# Altium schematic sheet PerifericaRelè.SchDoc(PerifericaRelè)
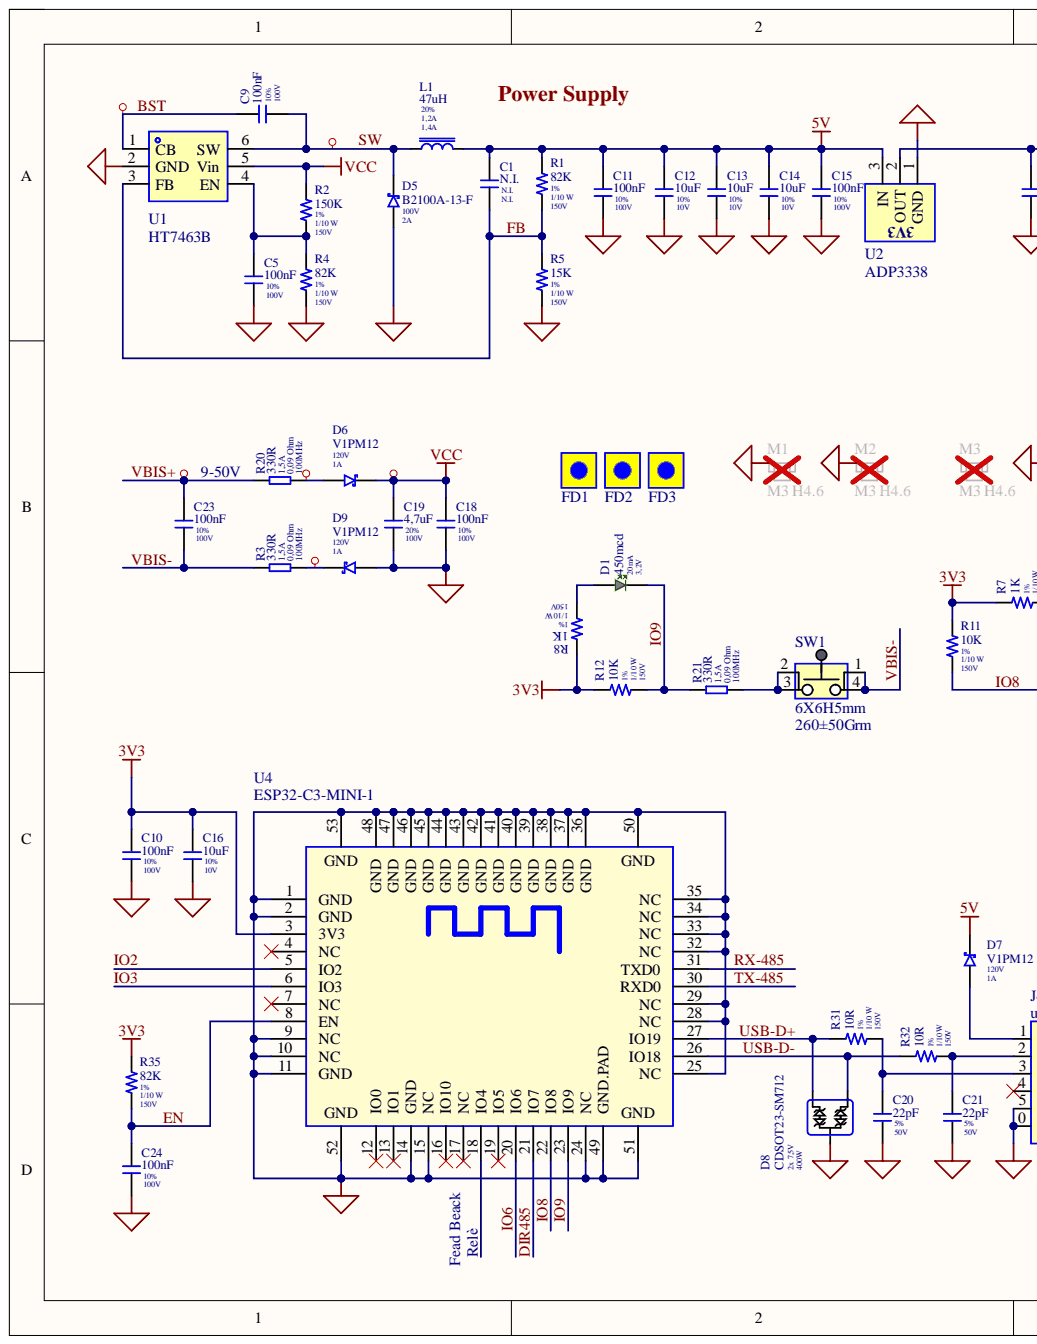
[diagram p0: PerifericaRelè.SchDoc, left]
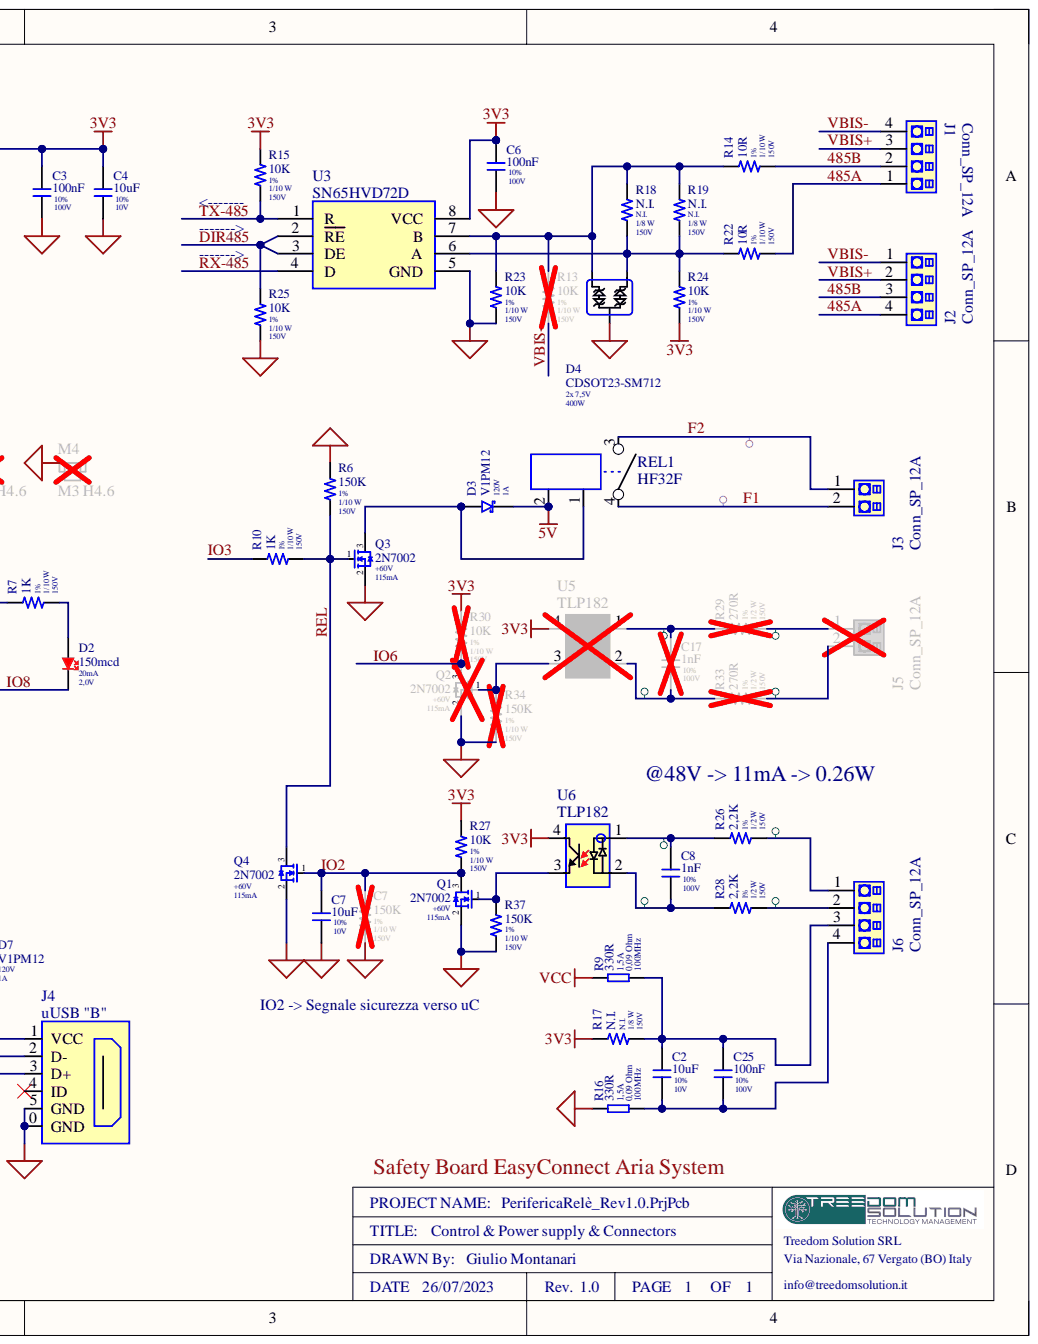
[diagram p1: PerifericaRelè.SchDoc, right]

## Parts
C1  N.I.  [CAP_0805]
C2  10uF  Tolerance: 10%  Voltage: 10V  [CAP_0805]
C3  100nF  Tolerance: 10%  Voltage: 100V  [CAP_0805]
C4  10uF  Tolerance: 10%  Voltage: 10V  [CAP_0805]
C5  100nF  Tolerance: 10%  Voltage: 100V  [CAP_0805]
C6  100nF  Tolerance: 10%  Voltage: 100V  [CAP_0805]
C7  150K  Power Rate: 1/10 W  Tolerance: 1%  Work Voltage: 150V  [RES_0805]
C7  10uF  Tolerance: 10%  Voltage: 10V  [CAP_0805]
C8  1nF  Tolerance: 10%  Voltage: 100V  [CAP_0805]
C9  100nF  Tolerance: 10%  Voltage: 100V  [CAP_0805]
C10  100nF  Tolerance: 10%  Voltage: 100V  [CAP_0805]
C11  100nF  Tolerance: 10%  Voltage: 100V  [CAP_0805]
C12  10uF  Tolerance: 10%  Voltage: 10V  [CAP_0805]
C13  10uF  Tolerance: 10%  Voltage: 10V  [CAP_0805]
C14  10uF  Tolerance: 10%  Voltage: 10V  [CAP_0805]
C15  100nF  Tolerance: 10%  Voltage: 100V  [CAP_0805]
C16  10uF  Tolerance: 10%  Voltage: 10V  [CAP_0805]
C17  1nF  Tolerance: 10%  Voltage: 100V  [CAP_0805]
C18  100nF  Tolerance: 10%  Voltage: 100V  [CAP_0805]
C19  4,7uF  Tolerance: 20%  Voltage: 100V  [CAP_1210]
C20  22pF  Tolerance: 5%  Voltage: 50V  [CAP_0805]
C21  22pF  Tolerance: 5%  Voltage: 50V  [CAP_0805]
C23  100nF  Tolerance: 10%  Voltage: 100V  [CAP_0805]
C24  100nF  Tolerance: 10%  Voltage: 100V  [CAP_0805]
C25  100nF  Tolerance: 10%  Voltage: 100V  [CAP_0805]
D1  450mcd  Current: 20mA  Voltage: 3,2V  [LED_G_0805]
D2  150mcd  Current: 20mA  Voltage: 2,0V  [LED_R_0805]
D3  V1PM12  Current MAX: 1A  Voltage MAX: 120V  [DO-219AD]
D4  CDSOT23-SM712  [SOT23]
D5  B2100A-13-F  Current MAX: 2A  Voltage MAX: 100V  [DO-214AC]
D6  V1PM12  Current MAX: 1A  Voltage MAX: 120V  [DO-219AD]
D7  V1PM12  Current MAX: 1A  Voltage MAX: 120V  [DO-219AD]
D8  CDSOT23-SM712  Power: 400W  Voltage: 2x 7,5V  [SOT23]
D9  V1PM12  Current MAX: 1A  Voltage MAX: 120V  [DO-219AD]
FD1  Fiducial  [FIDUCIAL_TL]  (value not drawn)  (no BOM)
FD2  Fiducial  [FIDUCIAL_TL]  (value not drawn)  (no BOM)
FD3  Fiducial  [FIDUCIAL_TL]  (value not drawn)  (no BOM)
J1  Conn_SP_12A  [LSF_SMT_3.50_04P]
J2  Conn_SP_12A  [LSF_SMT_3.50_04P]
J3  Conn_SP_12A  [LSF_SMT_3.50_02P]
J4  uUSB "B"  [Micro_USB_B_VE_PTH]
J5  Conn_SP_12A  [LSF_SMT_3.50_02P]
J6  Conn_SP_12A  [LSF_SMT_3.50_04P]
L1  Inductance: 47uH  Irms: 1,2A  Isat: 1,4A  Tolerance: 20%  [IND_6045]
M1  M3 H4.6  [Dist_SMD_REV_M3_H4.9]
M2  M3 H4.6  [Dist_SMD_REV_M3_H4.9]
M3  M3 H4.6  [Dist_SMD_REV_M3_H4.9]
M4  M3 H4.6  [Dist_SMD_REV_M3_H4.9]
Q1  2N7002  Current MAX: 115mA  Voltage MAX: +60V  [SOT23]
Q2  2N7002  Current MAX: 115mA  Voltage MAX: +60V  [SOT23]
Q3  2N7002  Current MAX: 115mA  Voltage MAX: +60V  [SOT23]
Q4  2N7002  Current MAX: 115mA  Voltage MAX: +60V  [SOT23]
R1  82K  Power Rate: 1/10 W  Tolerance: 1%  Work Voltage: 150V  [RES_0805]
R2  150K  Power Rate: 1/10 W  Tolerance: 1%  Work Voltage: 150V  [RES_0805]
R3  Current: 1,5A  DC Resistance: 0,09 Ohm  FRQ: 100MHz  Inductance: 330R  [FER_0805]  (hidden comment: Ferrite)
R4  82K  Power Rate: 1/10 W  Tolerance: 1%  Work Voltage: 150V  [RES_0805]
R5  15K  Power Rate: 1/10 W  Tolerance: 1%  Work Voltage: 150V  [RES_0805]
R6  150K  Power Rate: 1/10 W  Tolerance: 1%  Work Voltage: 150V  [RES_0805]
R7  1K  Power Rate: 1/10 W  Tolerance: 1%  Work Voltage: 150V  [RES_0805]
R8  1K  Power Rate: 1/10 W  Tolerance: 1%  Work Voltage: 150V  [RES_0805]
R9  Current: 1,5A  DC Resistance: 0,09 Ohm  FRQ: 100MHz  Inductance: 330R  [FER_0805]  (hidden comment: Ferrite)
R10  1K  Power Rate: 1/10 W  Tolerance: 1%  Work Voltage: 150V  [RES_0805]
R11  10K  Power Rate: 1/10 W  Tolerance: 1%  Work Voltage: 150V  [RES_0805]
R12  10K  Power Rate: 1/10 W  Tolerance: 1%  Work Voltage: 150V  [RES_0805]
R13  10K  Power Rate: 1/10 W  Tolerance: 1%  Work Voltage: 150V  [RES_0805]
R14  10R  Power Rate: 1/10 W  Tolerance: 1%  Work Voltage: 150V  [RES_0805]
R15  10K  Tolerance: 1%  Work Voltage: 150V  [RES_0805]
R16  Current: 1,5A  DC Resistance: 0,09 Ohm  FRQ: 100MHz  Inductance: 330R  [FER_0805]  (hidden comment: Ferrite)
R17  N.I.  Power Rate: 1/8 W  Work Voltage: 150V  [RES_0805]
R18  N.I.  Power Rate: 1/8 W  Work Voltage: 150V  [RES_0805]
R19  N.I.  Power Rate: 1/8 W  Work Voltage: 150V  [RES_0805]
R20  Current: 1,5A  DC Resistance: 0,09 Ohm  FRQ: 100MHz  Inductance: 330R  [FER_0805]  (hidden comment: Ferrite)
R21  Current: 1,5A  DC Resistance: 0,09 Ohm  FRQ: 100MHz  Inductance: 330R  [FER_0805]  (hidden comment: Ferrite)
R22  10R  Power Rate: 1/10 W  Tolerance: 1%  Work Voltage: 150V  [RES_0805]
R23  10K  Power Rate: 1/10 W  Tolerance: 1%  Work Voltage: 150V  [RES_0805]
R24  10K  Power Rate: 1/10 W  Tolerance: 1%  Work Voltage: 150V  [RES_0805]
R25  10K  Power Rate: 1/10 W  Tolerance: 1%  Work Voltage: 150V  [RES_0805]
R26  2,2K  Power Rate: 1/2 W  Tolerance: 1%  Work Voltage: 150V  [RES_0805]
R27  10K  Tolerance: 1%  Work Voltage: 150V  [RES_0805]
R28  2,2K  Power Rate: 1/2 W  Tolerance: 1%  Work Voltage: 150V  [RES_0805]
R29  270R  Power Rate: 1/2 W  Tolerance: 1%  Work Voltage: 150V  [RES_0805]
R30  10K  Tolerance: 1%  Work Voltage: 150V  [RES_0805]
R31  10R  Power Rate: 1/10 W  Tolerance: 1%  Work Voltage: 150V  [RES_0805]
R32  10R  Power Rate: 1/10 W  Tolerance: 1%  Work Voltage: 150V  [RES_0805]
R33  270R  Power Rate: 1/2 W  Tolerance: 1%  Work Voltage: 150V  [RES_0805]
R34  150K  Power Rate: 1/10 W  Tolerance: 1%  Work Voltage: 150V  [RES_0805]
R35  82K  Power Rate: 1/10 W  Tolerance: 1%  Work Voltage: 150V  [RES_0805]
R37  150K  Power Rate: 1/10 W  Tolerance: 1%  Work Voltage: 150V  [RES_0805]
REL1  HF32F  [REL_184x102h157]
SW1  6X6H5mm  Force: 260±50Grm  [PULS 6x6h5 SMD]
U1  HT7463B  [SOT23-6]
U2  ADP3338  [SOT223]
U3  SN65HVD72D  [SO-8]
U4  ESP32-C3-MINI-1  [ESP32-C3-MINI-1]
U5  TLP182  [SOP-4]
U6  TLP182  [SOP-4]

## Nets
3V3: C3.1 C4.1 C6.1 C10.1 C16.1 R7.1 R8.1 R11.1 R12.1 R15.1 R17.1 R24.2 R27.1 R30.1 R35.1 U2.2 U3.8(VCC) U4.3(3V3) U5.4 U6.4
5V: C1.1 C11.1 C12.1 C13.1 C14.1 C15.1 D3.K D7.K L1.2 R1.1 REL1.2 U2.3
GND: C3.2 C4.2 C5.2 C6.2 C7.2 C10.2 C11.2 C12.2 C13.2 C14.2 C15.2 C16.2 C18.2 C19.2 C20.2 C21.2 C24.2 D4.3 D5.A D8.3 D9.A J4.0(GND) J4.5(GND) M1.0 M2.0 M4.0 Q1.2 Q2.2 Q3.2 Q4.2 R4.2 R5.2 R6.1 R16.1 R23.2 R25.2 R34.2 R37.2 U1.2(GND) U2.1 U3.5(GND) U4.1(GND) U4.2(GND) U4.9(NC) U4.10(NC) U4.11(GND) U4.14(GND) U4.15(NC) U4.24(NC) U4.25(NC) U4.28(NC) U4.29(NC) U4.32(NC) U4.33(NC) U4.34(NC) U4.35(NC) U4.36(GND) U4.37(GND) U4.38(GND) U4.39(GND) U4.40(GND) U4.41(GND) U4.42(GND) U4.43(GND) U4.44(GND) U4.45(GND) U4.46(GND) U4.47(GND) U4.48(GND) U4.49(GND.PAD) U4.50 U4.51 U4.52 U4.53
VCC: C18.1 C19.1 D6.K R2.1 R9.1 U1.5(Vin)
485A: J1.1 J2.4 R22.2
485B: J1.2 J2.3 R14.2
BST: C9.1 U1.1(CB)
DIR485: R25.1 U3.2(RE) U3.3(DE) U4.21(IO7)
EN: C24.1 R35.2 U4.8(EN)
F1: J3.2 REL1.4
F2: J3.1 REL1.3
FB: C1.2 R1.2 R5.1 U1.3(FB)
IO2: C7.1 Q1.3 Q4.1 R27.2 U4.5(IO2)
IO3: R10.1 U4.6(IO3)
IO6: Q2.3 R30.2 U4.20(IO6)
IO8: D2.K R11.2 U4.22(IO8)
IO9: D1.K R12.2 R21.1 U4.23(IO9)
REL: Q3.1 Q4.3 R6.2 R10.2
RX-485: U3.4(D) U4.31(TXD0)
SW: C9.2 D5.K L1.1 U1.6(SW)
TX-485: R15.2 U3.1(R) U4.30(RXD0)
USB-D+: D8.1 R31.1 U4.27(IO19)
USB-D-: D8.2 R32.1 U4.26(IO18)
VBIS+: C23.1 J1.3 J2.2 R20.1
VBIS-: C23.2 J1.4 J2.1 R3.1 R13.2 SW1.1 SW1.4
NetC2_1: C2.1 C25.1 J6.3 R9.2 R17.2
NetC2_2: C2.2 C25.2 J6.4 R16.2
NetC5_1: C5.1 R2.2 R4.1 U1.4(EN)
NetC8_1: C8.1 R26.1 U6.1
NetC8_2: C8.2 R28.1 U6.2
NetC17_1: C17.1 R29.1 U5.1
NetC17_2: C17.2 R33.1 U5.2
NetC20_1: C20.1 J4.3(D+) R31.2
NetC21_1: C21.1 J4.2(D-) R32.2
NetD1_A: D1.A R8.2
NetD2_A: D2.A R7.2
NetD3_A: D3.A Q3.3 REL1.1
NetD4_1: D4.1 R13.1 R14.1 R18.1 R19.1 R23.1 U3.7(B)
NetD4_2: D4.2 R18.2 R19.2 R22.1 R24.1 U3.6(A)
NetD6_A: D6.A R20.2
NetD7_A: D7.A J4.1(VCC)
NetD9_K: D9.K R3.2
NetJ4_4: J4.4(ID)
NetJ5_1: J5.1 R29.2
NetJ5_2: J5.2 R33.2
NetJ6_1: J6.1 R26.2
NetJ6_2: J6.2 R28.2
NetM3_0: M3.0
NetQ1_1: Q1.1 R37.1 U6.3
NetQ2_1: Q2.1 R34.1 U5.3
NetR21_2: R21.2 SW1.2 SW1.3
NetU4_4: U4.4(NC)
NetU4_7: U4.7(NC)
NetU4_12: U4.12(IO0)
NetU4_13: U4.13(IO1)
NetU4_16: U4.16(IO10)
NetU4_17: U4.17(NC)
NetU4_18: U4.18(IO4)
NetU4_19: U4.19(IO5)

## Library data of the parts
C1 = N.I. 0805: Cap N.I. 0805; Database Table Name: Capacitors CERAMIC; Temp Range: -55°C to +125°C; Capacitance: N.I.; Component Kind: Standard; Library Name: Database_Libs.DbLib; Part Number: N.I. 0805; Price 1: 0; Tolerance: N.I.; Voltage: N.I.
C2 C4 C7 C12-C14 C16 = COCS 557061000106: Cap 10uF+/-10%;  10V; Case 0805; X5R; Manufactuer 1: Samsung Electro-Mechanics; Manufactuer 2: Taiyo Yoden  Taiyo Yuden; Manufactuer 3: Walsin; Database Table Name: Capacitors CERAMIC; Man 1 P\N: CL21A106KPFNNNE; Man 2 P\N: LMK212ABJ106KG-T; Man 3 P\N: 0805X106K100CT; Mounting Method: SMD; Temp Range: -55°C to +125°C; Capacitance: 10uF; Component Kind: Standard; ComponentLink1Description: Data Scheet Samsung; ComponentLink2Description: Data Scheet Taiyo Yoden; ComponentLink3Description: Data Scheet Walsin; Library Name: Database_Libs.DbLib; Part Number: COCS 557061000106; Price 1: 0,0139; Price 2: 0,0147; Price 3: 0,0216; ComponentLink1URL: C:\Sharing\Lib ALTIUM\DataBaseLib\DataScheet\Condensatori Ceramici\Samsung_CL.pdf; ComponentLink2URL: C:\Sharing\Lib ALTIUM\DataBaseLib\DataScheet\Condensatori Ceramici\TaiyoYuden_LMK212ABJ106KG-T.pdf; ComponentLink3URL: C:\Sharing\Lib ALTIUM\DataBaseLib\DataScheet\Condensatori Ceramici\Walsing_MMLC.pdf
C3 C5 C6 C9-C11 C15 C18 C23-C25 = COCS 557061010104: Cap 100nF+/-10%;  100V; Case 0805; X7R; Manufactuer 1: Samsung; Manufactuer 2: AVX; Manufactuer 3: Walsin; Database Table Name: Capacitors CERAMIC; Man 1 P\N: CL21B104KBCNNNC; Man 2 P\N: 08051C104KAT2A; Man 3 P\N: 0805B104K101CT; Mounting Method: SMD; Temp Range: -55°C to +125°C; Capacitance: 100nF; Component Kind: Standard; ComponentLink1Description: Data Scheet Samsung; ComponentLink2Description: Data Scheet AVX; ComponentLink3Description: Data Scheet Walsin; Library Name: Database_Libs.DbLib; Part Number: COCS 557061010104; Price 1: 0,0092; Price 2: 0,059; Price 3: 0,056; ComponentLink1URL: C:\Sharing\Lib ALTIUM\DataBaseLib\DataScheet\Condensatori Ceramici\Samsung_CL.pdf; ComponentLink2URL: C:\Sharing\Lib ALTIUM\DataBaseLib\DataScheet\Condensatori Ceramici\AVX_X7R.pdf; ComponentLink3URL: C:\Sharing\Lib ALTIUM\DataBaseLib\DataScheet\Condensatori Ceramici\Walsing_MMLC.pdf
C7 R2 R6 R34 R37 = RESS 4170310B0154: Res Thick Film; 150K+/-1%;  1/10W; Case 0805; 150V; Manufactuer 1: Kamaya; Man 1 P\N: RMC1/10154FTP; Mounting Method: SMD; Component Kind: Standard; ComponentLink1Description: Datascheet Kamaya; ComponentLink1URL: Datascheet\Resistors\Kamaya_RMC.pdf; Database Table Name: Resistors 0805; Library Name: Database_Libs.DbLib; Part Number: RESS 4170310B0154; Price 1: 0,02; Resistance: 150K
C8 C17 = COCS 557061010102: Cap 1nF+/-10%;  100V; Case 0805; X7R; Manufactuer 1: Johanson; Manufactuer 2: AVX; Manufactuer 3: Walsin; Database Table Name: Capacitors CERAMIC; Man 1 P\N: 101R15W102KV4T; Man 2 P\N: 08051C102KAT2A; Man 3 P\N: 0805B102K101CT; Mounting Method: SMD; Temp Range: -55°C to +125°C; Capacitance: 1nF; Component Kind: Standard; ComponentLink1Description: Datascheet Johanson; ComponentLink2Description: Datascheet AVX; ComponentLink3Description: Data Scheet Walsin; Library Name: Database_Libs.DbLib; Part Number: COCS 557061010102; Price 1: 0,0034; Price 2: 0,02; Price 3: 0,035; ComponentLink1URL: C:\Sharing\Lib ALTIUM\DataBaseLib\DataScheet\Condensatori Ceramici\Johanson_MLCC.pdf; ComponentLink2URL: C:\Sharing\Lib ALTIUM\DataBaseLib\DataScheet\Condensatori Ceramici\AVX_X7R.pdf; ComponentLink3URL: C:\Sharing\Lib ALTIUM\DataBaseLib\DataScheet\Condensatori Ceramici\Walsing_MMLC.pdf
C19 = COCS 552A71010475: Cap 4,7uF+/-20%;  100V; Case 1210; X7S; Manufactuer 1: Taiyo Yuden; Manufactuer 2: TDK; Manufactuer 3: PSA; Database Table Name: Capacitors CERAMIC; Man 1 P\N: HMK325C7475KN-TE; Man 2 P\N: C3225X7S2A475KT000N; Man 3 P\N: FS32X475K101EGG  FS32X475K101EGG; Mounting Method: SMD; Temp Range: -55°C to +125°C; Capacitance: 4,7uF; Component Kind: Standard; ComponentLink1Description: Datascheet; ComponentLink2Description: Data Scheet TDK; ComponentLink3Description: Data Scheet PSA; Library Name: Database_Libs.DbLib; Part Number: COCS 552A71010475; Price 1: 0,1256; Price 2: 0,3084; Price 3: 0,2297; ComponentLink1URL: C:\Sharing\Lib ALTIUM\DataBaseLib\DataScheet\Condensatori Ceramici\TaiyoYuden_LMK212ABJ106KG-T.pdf; ComponentLink2URL: C:\Sharing\Lib ALTIUM\DataBaseLib\DataScheet\Condensatori Ceramici\TDK_Cseries.pdf; ComponentLink3URL: C:\Sharing\Lib ALTIUM\DataBaseLib\DataScheet\Condensatori Ceramici\PSA-Prosperity-Dielectrics.pdf
C20 C21 = COCS 557065000220: Cap 22pF+/-5%;  50V; Case 0805; COG; Manufactuer 1: Samsung; Manufactuer 2: AVX; Manufactuer 3: Walsin; Database Table Name: Capacitors CERAMIC; Man 1 P\N: CL21C220JB61PNC; Man 2 P\N: 08055A220JAT2A; Man 3 P\N: 0805N220J500CT; Mounting Method: SMD; Temp Range: -55°C to +125°C; Capacitance: 22pF; Component Kind: Standard; ComponentLink1Description: Data Scheet Samsung; ComponentLink2Description: Data Scheet AVX; ComponentLink3Description: Data Scheet Walsin; Library Name: Database_Libs.DbLib; Part Number: COCS 557065000220; Price 1: 0,028; Price 2: 0,0182; Price 3: 0,023; ComponentLink1URL: C:\Sharing\Lib ALTIUM\DataBaseLib\DataScheet\Condensatori Ceramici\Samsung_CL.pdf; ComponentLink2URL: C:\Sharing\Lib ALTIUM\DataBaseLib\DataScheet\Condensatori Ceramici\AVX_C0G_NP0.pdf; ComponentLink3URL: C:\Sharing\Lib ALTIUM\DataBaseLib\DataScheet\Condensatori Ceramici\Walsing_MMLC.pdf
D1 = LEDS 9AC080GS7500: LED Green Waterclear; Case 0805; Vf 3,2V; If 20mA; 450mcd; Manufactuer 1: Wurth Elektronik; Database Table Name: Diode LED; Man 1 P\N: 150080GS75000; Mounting Method: SMD; Temp Range: -40°C to +85°C; Brightness: 450mcd; Component Kind: Standard; ComponentLink1Description: Datascheet WE; Library Name: Database_Libs.DbLib; Part Number: LEDS 9AC080GS7500; Price 1: 0,113; ComponentLink1URL: C:\Sharing\Lib ALTIUM\DataBaseLib\DataScheet\Diode\LED_G_150080GS75000.pdf
D2 = LEDS 2AC080RS7500: LED Red Waterclear; Case 0805; Vf 2,0V; If 20mA; 150mcd; Manufactuer 1: Wurth Elektronik; Database Table Name: Diode LED; Man 1 P\N: 150080RS75000; Mounting Method: SMD; Temp Range: -40°C to +85°C; Brightness: 150mcd; Component Kind: Standard; ComponentLink1Description: Datascheet WE; Library Name: Database_Libs.DbLib; Part Number: LEDS 2AC080RS7500; Price 1: 0,106; ComponentLink1URL: C:\Sharing\Lib ALTIUM\DataBaseLib\DataScheet\Diode\LED_R_150080RS75000.pdf
D3 D6 D7 D9 = DIOS 2SM000V1PM12: Schottky DiodeV1PM12; Vmax 120V; Imax 1A;Case DO-219AD-2; Manufactuer 1: DIODES; Manufactuer 2: ON Semiconductor; Database Table Name: Diode Rectifire; Man 1 P\N: V1PM12-M3/H   V1PM12-M3/H  V1PM12-M3/H  V1PM12-M3/H  V1PM12-M3/H; Mounting Method: SMD; Temp Range: -°C to +°C; COD: V1PM12; Component Kind: Standard; ComponentLink1Description: Data Scheet Vishay; Library Name: Database_Libs.DbLib; Part Number: DIOS 2SM000V1PM12; Price 1: 0,116; ComponentLink1URL: C:\Sharing\Lib ALTIUM\DataBaseLib\DataScheet\Diode\VISHAY_V1PM12-M3-H .pdf
D4 = ZENS 52350STO23SM712: Diode TVS; ESD Prot MAX 30KV; Vbr 2x7,5V; Ppk 400W; Manufactuer 1: Bourns; Database Table Name: Diode ZENER/ESD; Mounting Method: SMD; Tolerance: 10%; Voltage: 2x 7,5V; COD: CDSOT23-SM712; Component Kind: Standard; ComponentLink1Description: Datascheet Bourns; Library Name: Database_Libs.DbLib; Man 1 P\N: CDSOT23-SM712; Part Number: ZENS 52350STO23SM712; Power: 400W; Price 1: 0,55; ComponentLink1URL: C:\Sharing\Lib ALTIUM\DataBaseLib\DataScheet\Diode\DSOT23-SM712.pdf
D5 = DIOS 2150000B2100A: Schottky Diode B2100A-13-F; Vmax 100V; Imax 2A; DO-214AC (SMA); Manufactuer 1: DIODES; Manufactuer 2: ON Semiconductor; Database Table Name: Diode Rectifire; Man 2 P\N: MBRA2H100T3G; Mounting Method: SMD; Temp Range: -°C to +°C; COD: B2100A-13-F; Component Kind: Standard; ComponentLink1Description: Data Scheet Diodes; ComponentLink2Description: Data Scheet ON Semiconductor; Library Name: Database_Libs.DbLib; Man 1 P\N: B2100A-13-F; Part Number: DIOS 2150000B2100A; Price 1: 0,2001; Price 2: 0,6143; ComponentLink1URL: C:\Sharing\Lib ALTIUM\DataBaseLib\DataScheet\Diode\Diodes_B2100A.pdf; ComponentLink2URL: C:\Sharing\Lib ALTIUM\DataBaseLib\DataScheet\Diode\OnSemi_MBRS2H100-D.PDF
D8 = ZENS 52350STO23SM712: Diode TVS; ESD Prot MAX 30KV; Vbr 2x7,5V; Ppk 400W; Manufactuer 1: Bourns; Database Table Name: Diode ZENER/ESD; Mounting Method: SMD; Tolerance: 10%; COD: CDSOT23-SM712; Component Kind: Standard; ComponentLink1Description: Datascheet Bourns; Library Name: Database_Libs.DbLib; Man 1 P\N: CDSOT23-SM712; Part Number: ZENS 52350STO23SM712; Price 1: 0,55; ComponentLink1URL: C:\Sharing\Lib ALTIUM\DataBaseLib\DataScheet\Diode\DSOT23-SM712.pdf
FD1-FD3 = Fiducial: Reference P&P; Library Name: Mechanical.SchLib
J1 J2 J6 = Conn_SP_4P_12A_3.5mm: Conn SPRING TERMINAL; PCB Mount; 4pol; Imax 12A; Vmax 300V; Pich 3.5mm; Manufactuer 1: Wanje; Manufactuer 2: Weidmueller; Manufactuer 3: Dinke; Part Number: CON 300V12A4P350MMSP; Man 1 P\N: WJ212V-3.5-04P; Man 2 P\N: LSF-SMT 3.50/04/180 3.5SN BK TU; Man 3 P\N: 0138-5004; Component Kind: Standard; I max: 12A; Library Name: Connectors.SchLib; Price 1: 0,66; Vmax: 300V
J3 J5 = Conn_SP_2P_12A_3.5mm: Conn SPRING TERMINAL; PCB Mount; 2pol; Imax 12A; Vmax 300V; Pich 3.5mm; Manufactuer 1: Wanje; Manufactuer 2: Weidmueller; Manufactuer 3: Dinke; Part Number: CON 300V12A2P350MMSP; Man 1 P\N: WJ212V-3.5-02P; Man 2 P\N: LSF-SMT 3.50/02/180 3.5SN BK TU; Man 3 P\N: 0138-5002; Component Kind: Standard; I max: 12A; Library Name: Connectors.SchLib; Price 1: 0,45; Vmax: 300V
J4 = USB 2.0 Tipo Micro B Vertical: Micro USB 2.0 Tipo B  Vertical PTH; Manufactuer 1: GCT; Manufactuer 2: Korean Hroparts Elec; Part Number: MORS 0010USB313030A; Man 1 P\N: USB3130-30-A; Man 2 P\N: U-D-M5DD-W-1; Cod. JLCPCB: C145795; Cod. Mouser: 640-USB3130-30-A; Component Kind: Standard; ComponentLink1Description: Datascheet GCT; ComponentLink2Description: Datascheet Korean Hroparts Elec; Library Name: Connectors.SchLib; Mounting Method: PTH; Price 1: 0,46; ComponentLink1URL: C:\Sharing\Lib ALTIUM\Simple\DataScheet\USB\USB3130-30-A.pdf; ComponentLink2URL: C:\Sharing\Lib ALTIUM\Simple\DataScheet\USB\U-D-M5DD-W-1.pdf
L1 = IMPS 757371420470: Power. Ind. 6,0X6,0 H4,5mm; Ind.47uH; Irms 1,2A; Isat 1,4A;; Manufactuer 1: CENKER  SCE; Manufactuer 2: WE; Database Table Name: Inductance POW; Man 1 P\N: CKCS6045-47uH/M; Man 2 P\N: 74404064470; Mounting Method: SMD; Component Kind: Standard; ComponentLink1Description: Datascheet CENKER; ComponentLink2Description: Datascheet WE; Library Name: Database_Libs.DbLib; Part Number: IMPS 757371420470; Price 1: 0,0483; Price 2: 0,864; ComponentLink1URL: C:\Sharing\Lib ALTIUM\DataBaseLib\DataScheet\Inductor\CENKER-CKCS.pdf; ComponentLink2URL: C:\Sharing\Lib ALTIUM\DataBaseLib\DataScheet\Inductor\WE_74404064470.pdf
M1-M4 = Spacer_SMD_REV_M3_H4.6: Reverce Spacer; SMD; HD:3.2mm (M3); ID:4.2mm; OD:6mm; H:4.6mm; Manufactuer 1: WE; Manufactuer 2: SaFa Service; Part Number: DSOS 9775046960R; Man 1 P\N: 9775046960R; Man 2 P\N: 9775046960; Component Kind: Standard; ComponentLink1Description: Datascheet WE; Library Name: Mechanical.SchLib; Mounting Method: SMD; Price 1: 0,64; Price 2: 0,1876; ComponentLink1URL: C:\Sharing\Lib ALTIUM\Simple\DataScheet\Spacer\WE_9775046960R.pdf
Q1-Q4 = TRXS 110FD2N7002: Small Singan N-FET; Vds+60V; Id+115A; Vgs +/-20V; Vthmax 2,5V; Case SOT23; Manufactuer 1: ON Semiconductor; Database Table Name: Transistor; Man 1 P\N: 2N7002LT1G; Mounting Method: SMD; COD: 2N7002; Component Kind: Standard; ComponentLink1Description: Data ScheetOnSemi; Library Name: Database_Libs.DbLib; Part Number: TRXS 110FD2N7002; Price 1: 0,0708; Vgs: +/- 20V; ComponentLink1URL: C:\Sharing\Lib ALTIUM\DataBaseLib\DataScheet\Transistor\OnSemi_2N7002L-D.PDF
R1 R4 R35 = RESS 4170310B0823: Res Thick Film; 82K+/-1%;  1/10W; Case 0805; 150V; Manufactuer 1: Kamaya; Man 1 P\N: RMC1/10823FTP; Mounting Method: SMD; Component Kind: Standard; ComponentLink1Description: Datascheet Kamaya; ComponentLink1URL: Datascheet\Resistors\Kamaya_RMC.pdf; Database Table Name: Resistors 0805; Library Name: Database_Libs.DbLib; Part Number: RESS 4170310B0823; Price 1: 0,02; Resistance: 82K
R3 R9 R16 R20 R21 = INPS 718052010221: Fer 330@100MHz; 0,09ohm;  1,5A; Case 0805;; Manufactuer 1: Murata; Database Table Name: Ferriti SMD; Man 1 P\N: BLM21PG331SN1; Man 3 P\N: LQW18ANR22J8ZD; Mounting Method: SMD; Component Kind: Standard; ComponentLink1Description: Murata Datascheet; Library Name: Database_Libs.DbLib; Part Number: INPS 718052010221; Price 1: 0,2; ComponentLink1URL: C:\Sharing\Lib ALTIUM\DataBaseLib\DataScheet\Ferrite\Burata_BLM.pdf
R5 = RESS 4170310B0153: Res Thick Film; 15K+/-1%;  1/10W; Case 0805; 150V; Manufactuer 1: Kamaya; Man 1 P\N: RMC1/10153FTP; Mounting Method: SMD; Component Kind: Standard; ComponentLink1Description: Datascheet Kamaya; ComponentLink1URL: Datascheet\Resistors\Kamaya_RMC.pdf; Database Table Name: Resistors 0805; Library Name: Database_Libs.DbLib; Part Number: RESS 4170310B0153; Price 1: 0,02; Resistance: 15K
R7 R8 R10 = RESS 4170310B0102: Res Thick Film; 1K+/-1%;  1/10W; Case 0805; 150V; Manufactuer 1: Kamaya; Man 1 P\N: RMC1/10102FTP; Mounting Method: SMD; Component Kind: Standard; ComponentLink1Description: Datascheet Kamaya; ComponentLink1URL: Datascheet\Resistors\Kamaya_RMC.pdf; Database Table Name: Resistors 0805; Library Name: Database_Libs.DbLib; Part Number: RESS 4170310B0102; Price 1: 0,02; Resistance: 1K
R11-R13 R15 R23-R25 R27 R30 = RESS 4170310B0103: Res Thick Film; 10K+/-1%;  1/10W; Case 0805; 150V; Manufactuer 1: Kamaya; Man 1 P\N: RMC1/10103FTP; Mounting Method: SMD; Component Kind: Standard; ComponentLink1Description: Datascheet Kamaya; ComponentLink1URL: Datascheet\Resistors\Kamaya_RMC.pdf; Database Table Name: Resistors 0805; Library Name: Database_Libs.DbLib; Part Number: RESS 4170310B0103; Resistance: 10K
  R11-R13 R23-R25: Price 1: 0
  R15: Power Rate: 1/10 W; Price 1: 0
  R27 R30: Power Rate: 1/10 W; Price 1: 0,02
R14 R22 R31 R32 = RESS 4170310B0100: Res Thick Film; 10R+/-1%;  1/10W; Case 0805; 150V; Manufactuer 1: Kamaya; Man 1 P\N: RMC1/10100FTP; Mounting Method: SMD; Component Kind: Standard; ComponentLink1Description: Datascheet Kamaya; ComponentLink1URL: Datascheet\Resistors\Kamaya_RMC.pdf; Database Table Name: Resistors 0805; Library Name: Database_Libs.DbLib; Part Number: RESS 4170310B0100; Price 1: 0; Resistance: 10R
R17-R19 = N.I.: N.I.;  1/8W; Case 0805; 150V; Mounting Method: SMD; Component Kind: Standard; Database Table Name: Resistors 0805; Library Name: Database_Libs.DbLib; Part Number: N.I.; Price 1: 0; Resistance: N.I.; Tolerance: N.I.
R26 R28 = RESS 4170350B0222: Res Thick Film; 2,2K+/-1%; Hi power 1/2W; Case 0805; 150V; Manufactuer 1: Vishay / Dale; Manufactuer 2: Panasonic; Man 1 P\N: CRCW0805222RFKEAHP; Man 2 P\N: ERJ-P06F2202V; Mounting Method: SMD; Component Kind: Standard; ComponentLink1Description: Datascheet Vishay; ComponentLink1URL: Datascheet\Resistors\Vishay_CRCW-HP.pdf; ComponentLink2Description: Datascheet Panasonic; ComponentLink2URL: Datascheet\Resistors\Panasonic_ERJP.pdf; Database Table Name: Resistors 0805; Library Name: Database_Libs.DbLib; Part Number: RESS 4170350B0222; Price 1: 0,079; Price 2: 0,031; Resistance: 2,2K
R29 R33 = RESS 4170350B0271: Res Thick Film; 270R+/-1%;  1/2W; Case 0805; 150V; Manufactuer 1: Vishay / Dale; Manufactuer 2: Panasonic; Man 1 P\N: CRCW0805270RFKEAHP; Man 2 P\N: ERJ-P06F2700V; Mounting Method: SMD; Component Kind: Standard; ComponentLink1Description: Datascheet Vishay; ComponentLink1URL: Datascheet\Resistors\Vishay_CRCW-HP.pdf; ComponentLink2Description: Datascheet Panasonic; ComponentLink2URL: Datascheet\Resistors\Panasonic_ERJP.pdf; Database Table Name: Resistors 0805; Library Name: Database_Libs.DbLib; Part Number: RESS 4170350B0271; Price 1: 0,079; Price 2: 0,031; Resistance: 270R
REL1 = HF32F SPST-NO Vcoin 5V: Relay SPST-NO 10A 250VAC; Coil 5VDC; Case 18.4x10.2 H15.7; Manufactuer 1: NHLC; Manufactuer 2: HF; Manufactuer 3: TE Connectivity; Man 1 P\N: 932-5VDC-SL-A 10A/0.2W; Man 2 P\N: HF32F/005-HS; Man 3 P\N: 1461405-3; Mounting Method: PTH; Component Kind: Standard; ComponentLink1Description: Datascheet HF; ComponentLink2Description: Datascheet NHLC; ComponentLink3Description: Datascheet TE; Library Name: Relay.SchLib; Price 1: 0,31; Price 2: 0,319; Price 3: 0,85; ComponentLink1URL: C:\Sharing\Lib ALTIUM\Simple\DataScheet\Relay\HF_HF32F-005-HS_C192283.pdf; ComponentLink2URL: C:\Sharing\Lib ALTIUM\Simple\DataScheet\Relay\NHLC-932.pdf; ComponentLink3URL: C:\Sharing\Lib ALTIUM\Simple\DataScheet\Relay\TE-Connectivity-OEG.pdf
SW1 = Pusch SW H5mm SMD: Tiny Pushbutton Switche 6X6mm ; Height 5mm; SMD; Non-Illuminated; Ope.Force 260g; 12Vdc; 50mA; Manufactuer 1: WE; Manufactuer 2: C&K; Manufactuer 3: E Switch; Part Number: INT 15TL3301AF260QG; Man 1 P\N: 430152050826; Man 2 P\N: PTS645SK50SMTR92 LFS; Man 3 P\N: TL3301AF260QG; Component Kind: Standard; ComponentLink2Description: DataScheet; Library Name: Switch.SchLib; Mounting Method: SMD; Price 1: 0,336; Price 2: 0,122; Price 3: 0,291; ComponentLink2URL: C:\Sharing\Lib ALTIUM\Simple\DataScheet\Switch\WE_430152043836.pdf
U1 = ADJ HT7463B: Asynchronous Step Down Converter; InPut 4.5-52V 600mA; Out 1.0-0.9xVin; Frq Fix 550KHz; Case SOT23-6; Manufactuer 1: Holtek; Manufactuer 2: Texsas Instruments; Part Number: INGS 64000000HT7463B; Man 2 P\N: LV2862XLVDDCR; Mounting Method: SMD; Component Kind: Standard; ComponentLink1Description: Datascheet Holtek; ComponentLink2Description: Datascheet TI; Library Name: PowerSupply.SchLib; Man 1 P\N: HT7463B; Price 1: 0,2; Price 2: 1,06; ComponentLink1URL: C:\Sharing\Lib ALTIUM\Simple\DataScheet\Power Supply\Holtek_HT7463A.pdf; ComponentLink2URL: C:\Sharing\Lib ALTIUM\Simple\DataScheet\Power Supply\TI_LV2862.pdf
U2 = 3V3 ADP3338: ADP3338 UltraLOW-IQ; LDO Reg; 1A 3.3Vout; Case SOT223; Manufactuer 1: Analog Devices; Part Number: INGS 118000ADP3383V3; Man 1 P\N: ADP3338AKCZ-3.3RL7; Man 2 P\N: ADP3338AKCZ-3.3-RL; Mounting Method: SMD; Component Kind: Standard; ComponentLink1Description: Datascheet Analog Device; Library Name: PowerSupply.SchLib; Manufactuer 2: Analog Devices; Price 1: 1,4900; Price 2: 1,2900; ComponentLink1URL: C:\Sharing\Lib ALTIUM\Simple\DataScheet\Power Supply\ADP3338_Analog.pdf
U3 = SN65HVD72D: RS485 3v3 transceiver; Manufactuer 1: Texas Instruments; Part Number: INGS 00000SN65HVD72D; Mounting Method: SMD; Component Kind: Standard; ComponentLink1Description: Datascheet; Library Name: Integrated.SchLib; Man 1 P\N: SN65HVD72D; Price 1: 1,7200 €; ComponentLink1URL: C:\Sharing\Lib ALTIUM\Simple\DataScheet\sn65hvd72.pdf
U4 = ESP32-C3-MINI-1: ESP32-C3-MINI-1 Small­sized 2.4 GHz Wi­Fand Bluetooth 5 module; RISC­V single­core uC ESP32­C3; 4 MB flash; 15 GPIOs; On­board PCB antenna; Manufactuer 1: Espressif Systems; Part Number: INGS 000000ESP32C3M1; Man 1 P\N: ESP32-C3-MINI-1-H4; Mounting Method: SMD; Component Kind: Standard; ComponentLink1Description: Datascheet Espressif; ComponentLink2Description: Core_ESP32_DataScheet; Library Name: Microcontroller.SchLib; Price 1: 1,61; ComponentLink1URL: C:\Sharing\Lib ALTIUM\Simple\DataScheet\uC\ESP32-C3-MINI-1_Datasheet.pdf; ComponentLink2URL: C:\Sharing\Lib ALTIUM\Simple\DataScheet\uC\ESP32-C3_Datasheet.pdf
U5 U6 = TLP182: Infrared LED & Photo Transistor; Manufactuer 1: TOSHIBA; Mounting Method: SMD; Component Kind: Standard; ComponentLink1Description: Datascheet Toshiba; Library Name: OptoPart.SchLib; Man 1 P\N: TLP182; Price 1: 0,1260; ComponentLink1URL: C:\Sharing\Lib ALTIUM\Simple\DataScheet\TLP182_Toshiba.pdf
